# Altium schematic sheet Extra4_trigger.SchDoc
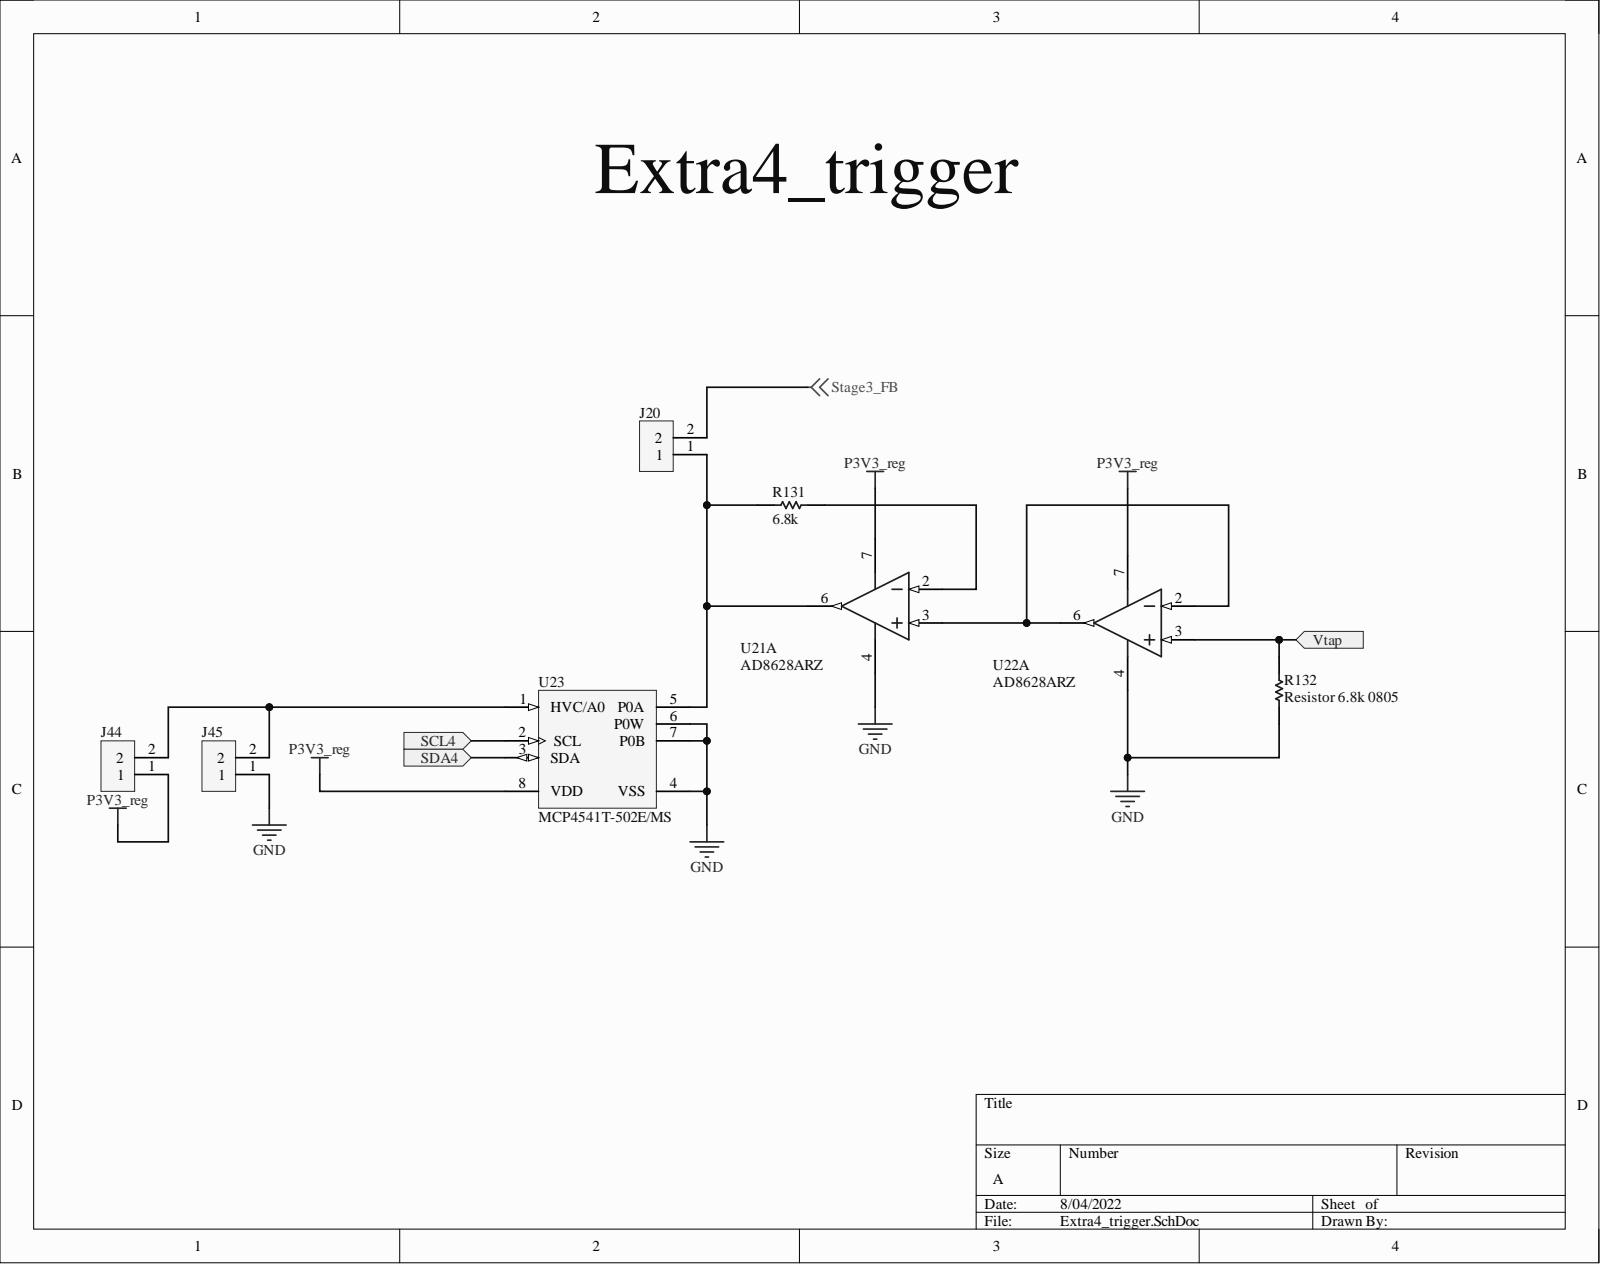
[diagram p0: Extra4_trigger.SchDoc]

## Parts
J20  (no value)  [PCB-omgsbkhp90n7lpri88og-1]
J44  (no value)  [PCB-omgsbkhp90n7lpri88og-1]
J45  (no value)  [PCB-omgsbkhp90n7lpri88og-1]
R131  6.8k  [RESC2013X55X40NL15T20]
R132  Resistor 6.8k 0805  [RESC2013X55X40NL15T20]
U21  AD8628ARZ  [ADI-R-8_L]  (part A)
U22  AD8628ARZ  [ADI-R-8_L]  (part A)
U23  MCP4541T-502E/MS  [MSOP-MS8_N]

## Ports
SCL4, SDA4, Vtap

## Nets
GND: J45.1 R132.1 U21.4 U22.4 U23.4(VSS) U23.6(P0W) U23.7(P0B)
P3V3_reg: J44.1 U21.7 U22.7 U23.8(VDD)
Stage3_FB: J20.2
SCL4: U23.2(SCL) ; port SCL4
SDA4: U23.3(SDA) ; port SDA4
Vtap: R132.2 U22.3 ; port Vtap
NetJ20_1: J20.1 R131.1 U21.6 U23.5(P0A)
NetJ44_2: J44.2 J45.2 U23.1(HVC/A0)
NetR131_2: R131.2 U21.2
NetU21_3: U21.3 U22.2 U22.6

## Library data of the parts
J20 J44 J45 = CMP-748fbcb73d6bab48-30: Pitch 2.54 mm, Single Row, 2pins; Component Kind: Standard; Library Name: My Personal Space
R131 = CMP-1953953-15: RES SMD 6.8K OHM 0.1% 1/8W 0805; Manufacturer Part Number: TNPW080510K0BEEN; Case/Package (SI): 2012; Lead-Free Status: Lead Free; Power Rating: 0.125 W; ReplaceComponentId: VAMDRZDP; Resistance: 6800.0 Ω; Temperature Coefficient: 25.0 ppm/°C; Voltage Rating (DC): 150.0 V; Case/Package: 0805; Component Kind: Standard; Library Name: My Personal Space; Number of Pins: 2; OctopartID: 2ed9e58acfb9f11e; Packaging: Tape & Reel (TR); PartId: 1; Resistance Tolerance: ±0.1%; RoHS: Compliant
R132 = CMP-1953953-15: RES SMD 6.8K OHM 0.1% 1/8W 0805; Manufacturer Part Number: TNPW080510K0BEEN; Case/Package (SI): 2012; Lead-Free Status: Lead Free; Power Rating: 0.125 W; ReplaceComponentId: LXFCLBAZ; Resistance: 6800.0 Ω; Temperature Coefficient: 25.0 ppm/°C; Voltage Rating (DC): 150.0 V; Case/Package: 0805; Component Kind: Standard; Library Name: My Personal Space; Number of Pins: 2; OctopartID: 2ed9e58acfb9f11e; Packaging: Tape & Reel (TR); PartId: 1; Resistance Tolerance: ±0.1%; RoHS: Compliant
U21 U22 = CMP-2260891-3: Single Channel RRIO Operational Amplifier, 2.5 MHz BW, 1 V/us SR, Industrial, 8-pin SOIC (R-8), Tube; Bandwidth: 2500000.0 Hz; Common Mode Rejection Ratio: 140.0 dB; Input Capacitance: 1e-11 F; Input Impedance: 5e+11 Ω; Lead-Free Status: Contains Lead; Mil-Spec: No; Number of Channels: 1; Slew Rate: 1.0 V/μs; Supply Current: 0.0011 A; Supply Voltage (DC): 5.0 V; Case/Package: SOIC; Component Kind: Standard; Gain Bandwidth Product: 2500000.0 Hz; Library Name: My Personal Space; Lifecycle Status: Active; Manufacturer Part Number: AD8628ARZ; Mounting Style: Surface Mount; Number of Circuits: 1; Number of Pins: 8; Octopart Url: https://octopart.com/ad8628arz-analog+devices-412641; OctopartID: cd22042ea3a512e5; Packaging: Tube; REACH SVHC Compliance: No SVHC; RoHS: Compliant
U23 = CMP-1074023-3: 7-Bit Single I2C Digital POT with Non-Volatile Memory, 8-pin MSOP, Extended Temperature, Tape and Reel; Resistance Tolerance: ±20%; Component Kind: Standard; Library Name: My Personal Space; Lifecycle Status: Active; Manufacturer Part Number: MCP4541T-502E/MS; Octopart Url: https://octopart.com/mcp4541t-502e%2Fms-microchip-16787150; OctopartID: 3faff7a53c07e068; RoHS: Compliant
